# Altium schematic sheet Sheet1.SchDoc
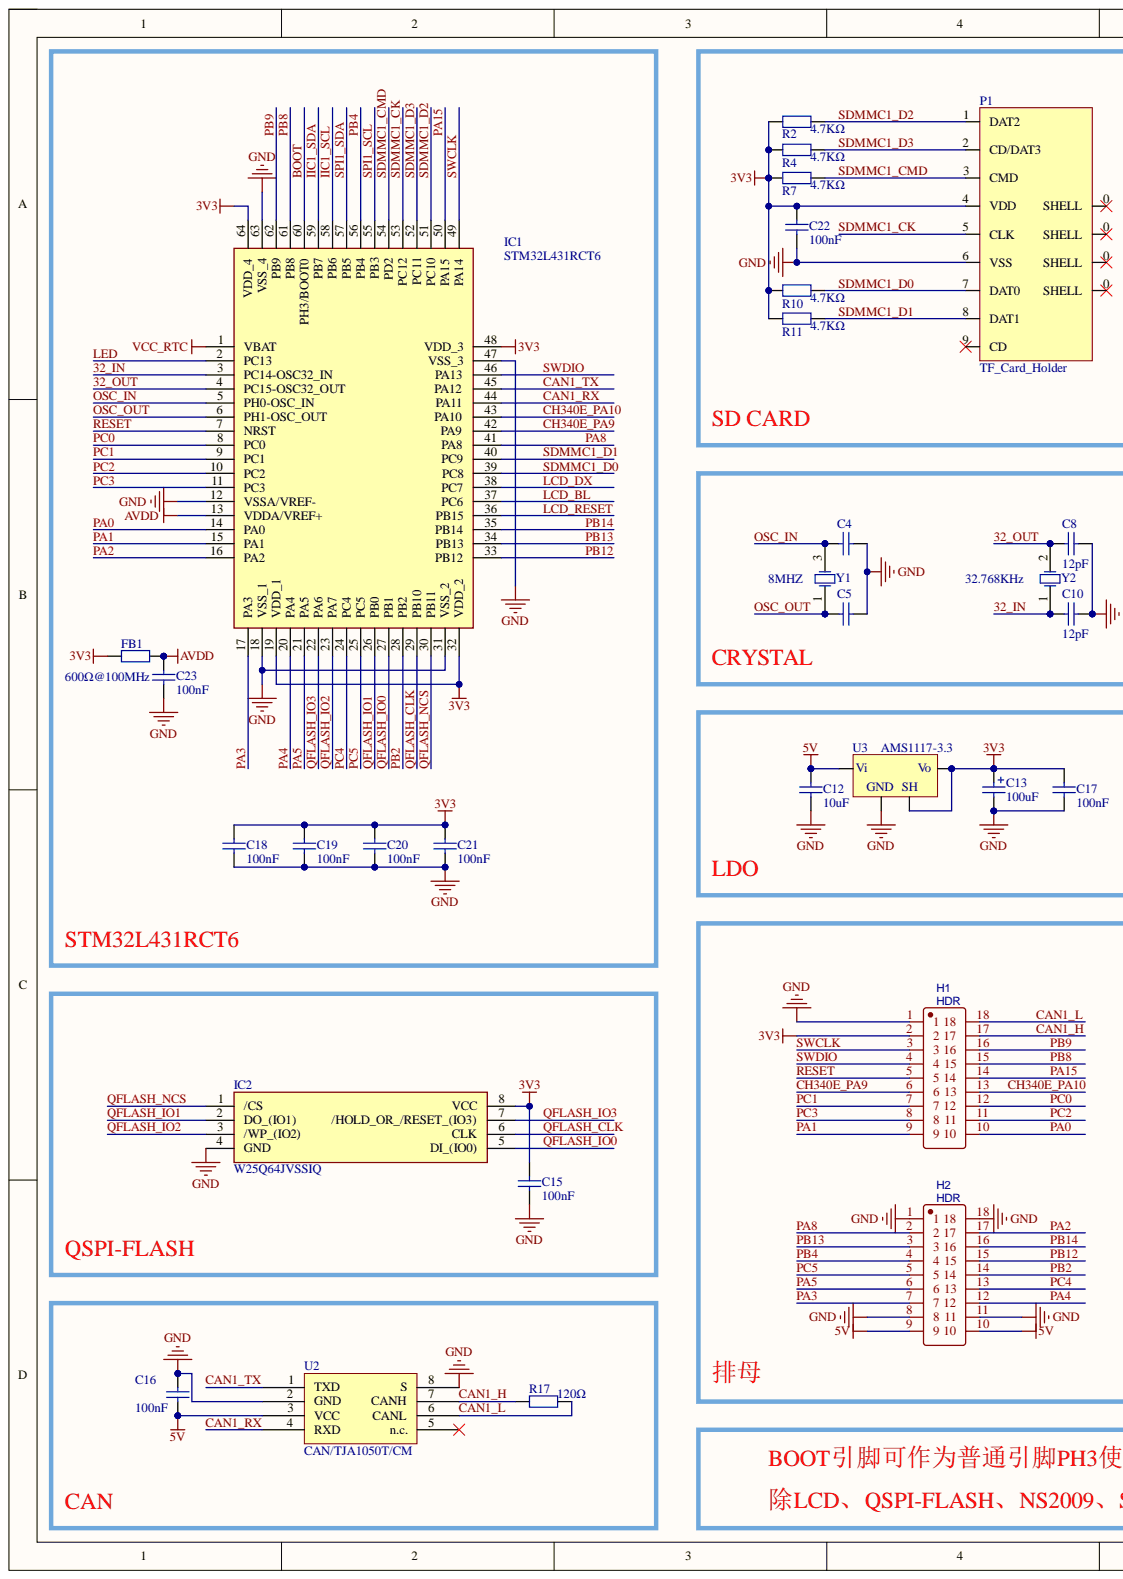
[diagram p0: Sheet1.SchDoc, left]
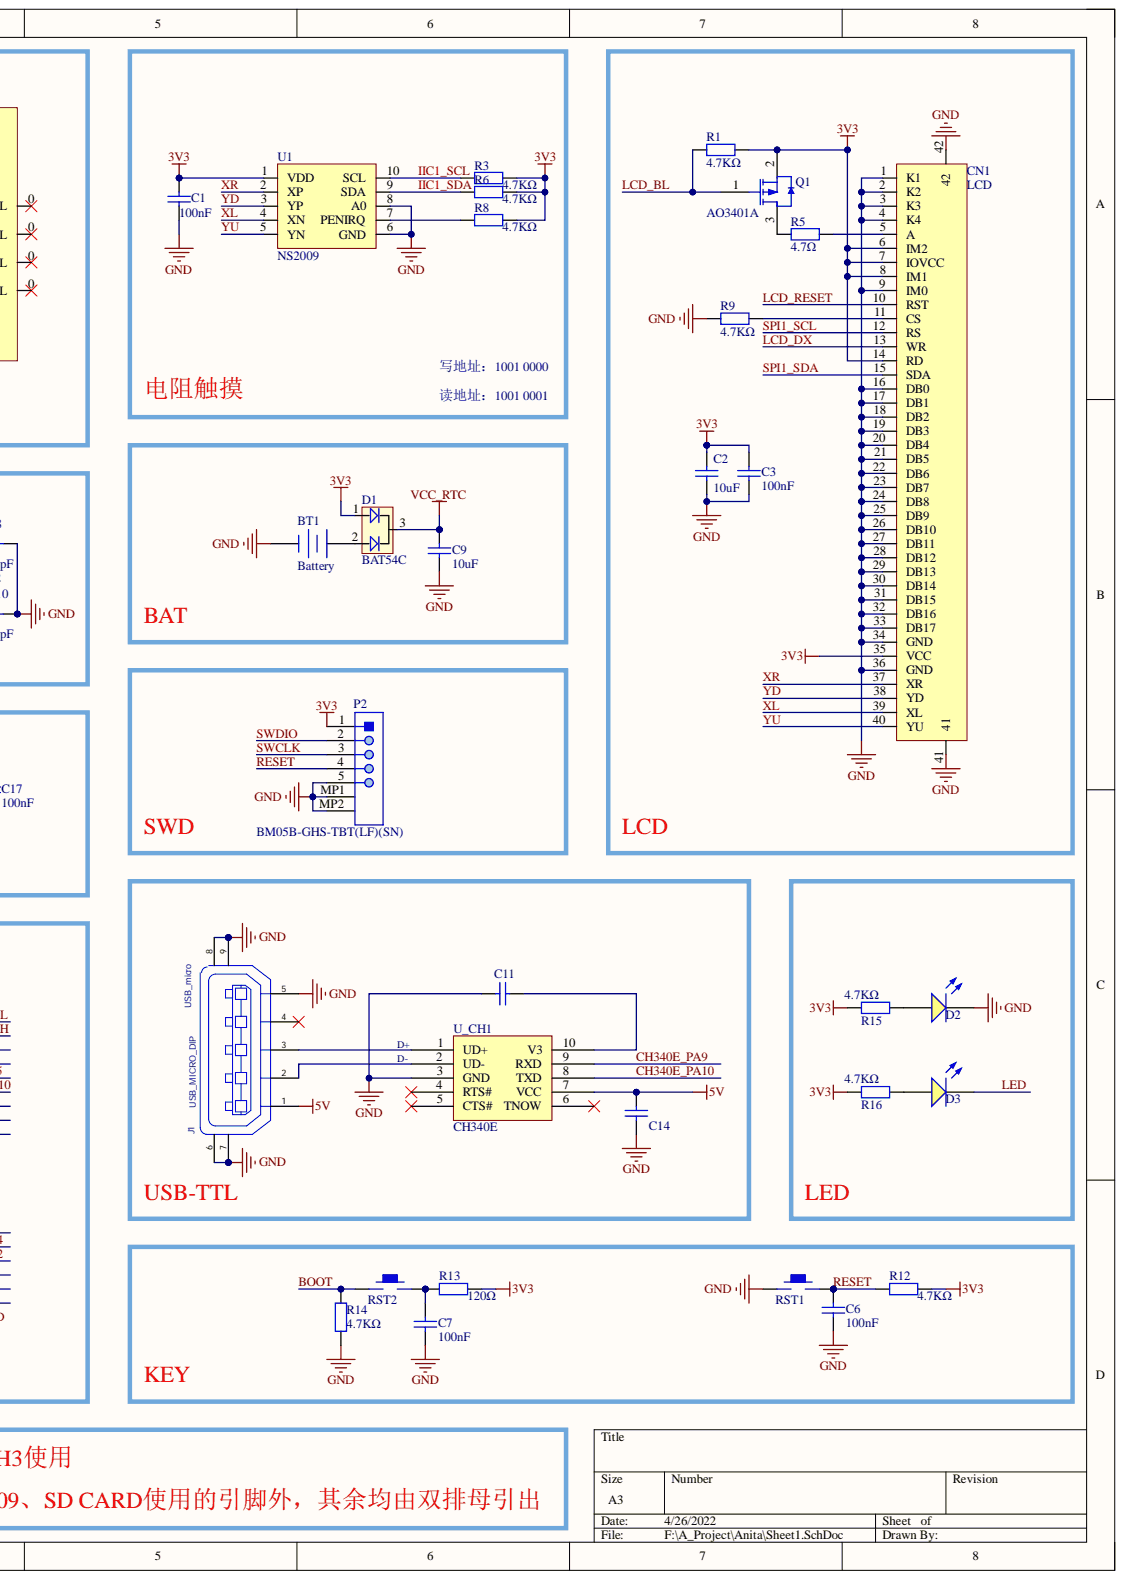
[diagram p1: Sheet1.SchDoc, right]

## Parts
BT1  Battery  [BATTERY]
C1  100nF  [0402_C]
C2  10uF  [0603_C]
C3  100nF  [0402_C]
C4  12pF  [0402_C]  (value not drawn)
C5  12pF  [0402_C]  (value not drawn)
C6  100nF  [0402_C]
C7  100nF  [0402_C]
C8  12pF  [0402_C]
C9  10uF  [0603_C]
C10  12pF  [0402_C]
C11  100nF (104) 10% 50V  [0402_C]  (value not drawn)
C12  10uF  [0603_C]
C13  100uF  [CASE-B_3528]
C14  100nF (104) 10% 50V  [0402_C]  (value not drawn)
C15  100nF  [0402_C]
C16  100nF  [0402_C]
C17  100nF  [0402_C]
C18  100nF  [0402_C]
C19  100nF  [0402_C]
C20  100nF  [0402_C]
C21  100nF  [0402_C]
C22  100nF  [0402_C]
C23  100nF  [0402_C]
CN1  LCD  [FPC-SMD_40P-P0.50_HDGC_0.5K-DX-40PWB]
D1  BAT54C  [SOT95P240X120-3N]
D2  黄灯 0603  [0603_LED_S1]  (value not drawn)
D3  黄灯 0603  [0603_LED_S1]  (value not drawn)
FB1  600Ω@100MHz  [0603_R]
H1  HDR  [HDR-SMD_18P-P2.54-V-M-R2-C9-S7.50]
H2  HDR  [HDR-SMD_18P-P2.54-V-M-R2-C9-S7.50]
IC1  STM32L431RCT6  [QFP50P1200X1200X160-64N]
IC2  W25Q64JVSSIQ  [SOIC127P790X216-8N]
J1  USB_MICRO_DIP  value USB_micro  [MICRO_USB_5S]
P1  TF_Card_Holder  [SD-MICRO-TF]
P2  BM05B-GHS-TBT(LF)(SN)  [CONN-SMD_5P-P1.25_HCTL_HC-1.25-5PWT]
Q1  AO3401A  [SOT-23-3L]
R1  4.7KΩ  [0402_R]
R2  4.7KΩ  [0402_R]
R3  4.7KΩ  [0402_R]
R4  4.7KΩ  [0402_R]
R5  4.7Ω  [0402_R]
R6  4.7KΩ  [0402_R]
R7  4.7KΩ  [0402_R]
R8  4.7KΩ  [0402_R]
R9  4.7KΩ  [0402_R]
R10  4.7KΩ  [0402_R]
R11  4.7KΩ  [0402_R]
R12  4.7KΩ  [0402_R]
R13  120Ω  [0402_R]
R14  4.7KΩ  [0402_R]
R15  4.7KΩ  [0402_R]
R16  4.7KΩ  [0402_R]
R17  120Ω  [0402_R]
RST1  S-KEY-SMD-324225  [KEY-SOP324225]  (value not drawn)
RST2  S-KEY-SMD-324225  [KEY-SOP324225]  (value not drawn)
U1  NS2009  [MSOP-10_L3.0-W3.0-P0.50-LS5.0-BL]
U2  CAN/TJA1050T/CM  [SOIC-8_150mil]
U3  AMS1117-3.3  [SOT-223]
U_CH1  CH340E  [MSOP-10_L3.0-W3.0-P0.50-LS5.0-BL]
Y1  8MHZ  [SMD-3225_4P]
Y2  32.768KHz  [SMD-3215_2P FC - 135]

## Nets
3V3: C1.1 C2.2 C3.2 C13.1 C15.1 C17.1 C18.1 C19.1 C20.1 C21.1 C22.1 CN1.6(IM2) CN1.7(IOVCC) CN1.8(IM1) CN1.14(RD) CN1.35(VCC) D1.1 FB1.1 H1.2 IC1.19(VDD_1) IC1.32(VDD_2) IC1.48(VDD_3) IC1.64(VDD_4) IC2.8(VCC) P1.4(VDD) P2.1 Q1.2 R1.2 R2.1 R3.2 R4.1 R6.2 R7.1 R8.2 R10.2 R11.2 R12.1 R13.2 R15.2 R16.2 U1.1(VDD) U3.2(Vo) U3.4(SH)
5V: C12.2 C14.2 C16.2 H2.9 H2.10 J1.1 U2.3(VCC) U3.3(Vi) U_CH1.7(VCC)
AVDD: C23.2 FB1.2 IC1.13(VDDA/VREF+)
GND: BT1.2 C1.2 C2.1 C3.1 C4.2 C5.2 C6.2 C7.2 C8.1 C9.1 C10.1 C11.1 C12.1 C13.2 C14.1 C15.2 C16.1 C17.2 C18.2 C19.2 C20.2 C21.2 C22.2 C23.1 CN1.1(K1) CN1.2(K2) CN1.3(K3) CN1.4(K4) CN1.9(IM0) CN1.16(DB0) CN1.17(DB1) CN1.18(DB2) CN1.19(DB3) CN1.20(DB4) CN1.21(DB5) CN1.22(DB6) CN1.23(DB7) CN1.24(DB8) CN1.25(DB9) CN1.26(DB10) CN1.27(DB11) CN1.28(DB12) CN1.29(DB13) CN1.30(DB14) CN1.31(DB15) CN1.32(DB16) CN1.33(DB17) CN1.34(GND) CN1.36(GND) CN1.41 CN1.42 D2.K H1.1 H2.1 H2.8 H2.11 H2.18 IC1.12(VSSA/VREF-) IC1.18(VSS_1) IC1.31(VSS_2) IC1.47(VSS_3) IC1.63(VSS_4) IC2.4(GND) J1.5 J1.6 J1.7 J1.8 J1.9 P1.6(VSS) P2.5 P2.MP1 P2.MP2 R9.2 R14.2 RST1.2 U1.6(GND) U1.8(A0) U2.2(GND) U2.8(S) U3.1 U_CH1.3(GND)
VCC_RTC: C9.2 D1.3 IC1.1(VBAT)
32_IN: C10.2 IC1.3(PC14-OSC32_IN) Y2.1
32_OUT: C8.2 IC1.4(PC15-OSC32_OUT) Y2.2
BOOT: IC1.60(PH3/BOOT0) R14.1 RST2.2
CAN1_H: H1.17 R17.1 U2.7(CANH)
CAN1_L: H1.18 R17.2 U2.6(CANL)
CAN1_RX: IC1.44(PA11) U2.4(RXD)
CAN1_TX: IC1.45(PA12) U2.1(TXD)
CH340E_PA9: H1.6 IC1.42(PA9) U_CH1.9(RXD)
CH340E_PA10: H1.13 IC1.43(PA10) U_CH1.8(TXD)
IIC1_SCL: IC1.58(PB6) R3.1 U1.10(SCL)
IIC1_SDA: IC1.59(PB7) R6.1 U1.9(SDA)
LCD_BL: IC1.37(PC6) Q1.1 R1.1
LCD_DX: CN1.13(WR) IC1.38(PC7)
LCD_RESET: CN1.10(RST) IC1.36(PB15)
LED: D3.K IC1.2(PC13)
OSC_IN: C4.1 IC1.5(PH0-OSC_IN) Y1.3
OSC_OUT: C5.1 IC1.6(PH1-OSC_OUT) Y1.1
PA0: H1.10 IC1.14(PA0)
PA1: H1.9 IC1.15(PA1)
PA2: H2.17 IC1.16(PA2)
PA3: H2.7 IC1.17(PA3)
PA4: H2.12 IC1.20(PA4)
PA5: H2.6 IC1.21(PA5)
PA8: H2.2 IC1.41(PA8)
PA15: H1.14 IC1.50(PA15)
PB2: H2.14 IC1.28(PB2)
PB4: H2.4 IC1.56(PB4)
PB8: H1.15 IC1.61(PB8)
PB9: H1.16 IC1.62(PB9)
PB12: H2.15 IC1.33(PB12)
PB13: H2.3 IC1.34(PB13)
PB14: H2.16 IC1.35(PB14)
PC0: H1.12 IC1.8(PC0)
PC1: H1.7 IC1.9(PC1)
PC2: H1.11 IC1.10(PC2)
PC3: H1.8 IC1.11(PC3)
PC4: H2.13 IC1.24(PC4)
PC5: H2.5 IC1.25(PC5)
QFLASH_CLK: IC1.29(PB10) IC2.6(CLK)
QFLASH_IO0: IC1.27(PB1) IC2.5(DI_(IO0))
QFLASH_IO1: IC1.26(PB0) IC2.2(DO_(IO1))
QFLASH_IO2: IC1.23(PA7) IC2.3(/WP_(IO2))
QFLASH_IO3: IC1.22(PA6) IC2.7(/HOLD_OR_/RESET_(IO3))
QFLASH_NCS: IC1.30(PB11) IC2.1(/CS)
RESET: C6.1 H1.5 IC1.7(NRST) P2.4 R12.2 RST1.1
SDMMC1_CK: IC1.53(PC12) P1.5(CLK)
SDMMC1_CMD: IC1.54(PD2) P1.3(CMD) R7.2
SDMMC1_D0: IC1.39(PC8) P1.7(DAT0) R10.1
SDMMC1_D1: IC1.40(PC9) P1.8(DAT1) R11.1
SDMMC1_D2: IC1.51(PC10) P1.1(DAT2) R2.2
SDMMC1_D3: IC1.52(PC11) P1.2(CD/DAT3) R4.2
SPI1_SCL: CN1.12(RS) IC1.55(PB3)
SPI1_SDA: CN1.15(SDA) IC1.57(PB5)
SWCLK: H1.3 IC1.49(PA14) P2.3
SWDIO: H1.4 IC1.46(PA13) P2.2
XL: CN1.39(XL) U1.4(XN)
XR: CN1.37(XR) U1.2(XP)
YD: CN1.38(YD) U1.3(YP)
YU: CN1.40(YU) U1.5(YN)
NetBT1_1: BT1.1 D1.2
NetC7_1: C7.1 R13.1 RST2.1
NetC11_2: C11.2 U_CH1.10(V3)
NetCN1_5: CN1.5(A) R5.2
NetCN1_11: CN1.11(CS) R9.1
NetD2_A: D2.A R15.1
NetD3_A: D3.A R16.1
NetJ1_2: J1.2 U_CH1.2(UD-)
NetJ1_3: J1.3 U_CH1.1(UD+)
NetQ1_3: Q1.3 R5.1
NetR8_1: R8.1 U1.7(PENIRQ)

Not connected: J1 4; P1 0(SHELL) 9(CD); U2 5(n.c.); U_CH1 4(RTS#) 5(CTS#) 6(TNOW)

## Library data of the parts
BT1 = Battery: Multicell Battery; Component Kind: Standard; LatestRevisionDate: 17-Jul-2002; LatestRevisionNote: Re-released for DXP Platform.; Library Name: Schlib1.SchLib; PackageDescription: Battery; 2 Leads; PackageReference: BAT-2; Published: 24-Mar-1999; Publisher: Altium Limited
C1 C3 C6 C7 C15-C23 = 0402 100nF (104) 10% 16V: 贴片电容; Component Kind: Standard; ComponentLink1Description: 供应商链接; ComponentLink1URL: http://www.szlcsc.com/product/details_1877.html; Library Name: Miscellaneous Devices LC.IntLib; Package: 0402; Supplier: LC; SuppliersPartNumber: C1525
C2 = 0603 10uF (106) 10% 10V: 贴片电容; Component Kind: Standard; ComponentLink1Description: 供应商链接; ComponentLink1URL: http://www.szlcsc.com/product/details_20411.html; Library Name: Miscellaneous Devices LC.IntLib; Package: 0603; Supplier: LC; SuppliersPartNumber: C19702
C4 C5 = 0402 20pF (200) 5% 50V: 贴片电容; Component Kind: Standard; ComponentLink1Description: 供应商链接; ComponentLink1URL: http://www.szlcsc.com/product/details_1906.html; Library Name: Miscellaneous Devices LC.IntLib; Package: 0402; Supplier: LC; SuppliersPartNumber: C1554
C8 C10 = 0402 10pF (100) 5% 50V: 贴片电容; Component Kind: Standard; ComponentLink1Description: 供应商链接; ComponentLink1URL: http://www.szlcsc.com/product/details_33914.html; Library Name: Miscellaneous Devices LC.IntLib; Package: 0402; Supplier: LC; SuppliersPartNumber: C32949
C9 = 0603 4.7uF (475) 10% 16V: 贴片电容; Component Kind: Standard; ComponentLink1Description: 供应商链接; ComponentLink1URL: http://www.szlcsc.com/product/details_20375.html; Library Name: Miscellaneous Devices LC.IntLib; Package: 0603; Supplier: LC; SuppliersPartNumber: C19666
C11 C14 = 0603 100nF (104) 10% 50V: 贴片电容; Component Kind: Standard; ComponentLink1Description: 供应商链接; ComponentLink1URL: http://www.szlcsc.com/product/details_15331.html; Library Name: Miscellaneous Devices LC.IntLib; Package: 0603; Supplier: LC; SuppliersPartNumber: C14663
C12 = 0805 10uF (106) 10% 25V: 贴片电容; Component Kind: Standard; ComponentLink1Description: 供应商链接; ComponentLink1URL: http://www.szlcsc.com/product/details_16532.html; Library Name: Miscellaneous Devices LC.IntLib; Package: 0805; Supplier: LC; SuppliersPartNumber: C15850
C13 = 3528 100uF (107) 10% 6.3V: 钽电容; Component Kind: Standard; ComponentLink1Description: 供应商链接; ComponentLink1URL: http://www.szlcsc.com/product/details_16815.html; Library Name: Miscellaneous Devices LC.IntLib; Package: 3528; Supplier: LC; SuppliersPartNumber: C16133
CN1 = FPC_40P_LCD: Component Kind: Standard; Library Name: K210_Core.SCHLIB
D1 = BAT54C: 双肖特基二极管; Component Kind: Standard; Library Name: SamacSys.SchLib
D2 D3 = 0603 黄灯: 发光二极管; Component Kind: Standard; ComponentLink1Description: 供应商链接; ComponentLink1URL: http://www.szlcsc.com/product/details_73147.html; Library Name: Miscellaneous Devices LC.IntLib; Package: 0603; Supplier: LC; SuppliersPartNumber: C72038
FB1 = 0603 100Ω (1000) 1%: 贴片电阻; Component Kind: Standard; ComponentLink1Description: 供应商链接; ComponentLink1URL: http://www.szlcsc.com/product/details_23502.html; Library Name: Miscellaneous Devices LC.IntLib; Package: 0603; Supplier: LC; SuppliersPartNumber: C22775
H1 H2 = HDR: BOM_Manufacturer: BOOMELE(博穆精密); BOM_Manufacturer Part: 2.54-2*9P立贴针带柱; Contributor: LCSC; BOM_JLCPCB Part Class: Extended Part; BOM_Supplier: LCSC; BOM_Supplier Part: C88175; Component Kind: Standard; spicePre: H; spiceSymbolName: HDR
IC1 = STM32L431RCT6: Integrated Circuit; Manufacturer_Name: STMicroelectronics; Arrow Part Number: STM32L431RCT6; Arrow Price/Stock: https://www.arrow.com/en/products/stm32l431rct6/stmicroelectronics?region=nac; Component Kind: Standard; Datasheet Link: https://www.st.com/resource/en/datasheet/stm32l431rc.pdf; Height: 1.6mm; Manufacturer_Part_Number: STM32L431RCT6; Mouser Part Number: 511-STM32L431RCT6; Mouser Price/Stock: https://www.mouser.co.uk/ProductDetail/STMicroelectronics/STM32L431RCT6?qs=U58vgkJzipQt4Fiogdks1w%3D%3D
IC2 = W25Q128FVSIG: Integrated Circuit; Manufacturer_Name: Winbond; Arrow Part Number: W25Q128FVSIG; Arrow Price/Stock: https://www.arrow.com/en/products/w25q128fvsig/winbond-electronics; Component Kind: Standard; Datasheet Link: https://www.winbond.com/resource-files/w25q128fv rev.m 05132016 kms.pdf; Height: 2.16mm; Manufacturer_Part_Number: W25Q128FVSIG; Mouser Part Number: N/A; Mouser Price/Stock: https://www.mouser.co.uk/ProductDetail/Winbond/W25Q128FVSIG?qs=rkhjVJ6%2F3EIwu4pV702YLQ%3D%3D
P2 = BM05B-GHS-TBT(LF)(SN): C2845382; Manufacturer: JST; No of Positions: 5; Pitch: 1.25mm; Style/Orientation: StraightHeader/Plug; Component Kind: Standard; Datasheet URL: http://www.jst-mfg.com/product/pdf/eng/eGH.pdf; Datasheet Version: 05/2016; Library Name: JST GH connector.IntLib; Manufacturer URL: http://www.jst.com/home2.html; Mounting Technology: SurfaceMount; Package Description: GH Disconnectable Crimp Style Connector, Pitch 1.25 mm, 1 x 5 Position, Height 4.05 mm; Package Reference: BM05B-GHS-TBT; Termination: Crimp; Type: WiretoBoard
Q1 = AO3401A P道沟 X13A15 SOT-23: MOS(场效应管); Component Kind: Standard; ComponentLink1Description: 供应商链接; ComponentLink1URL: http://www.szlcsc.com/product/details_15801.html; Library Name: Miscellaneous Devices LC.IntLib; Package: SOT-23; Supplier: LC; SuppliersPartNumber: C15127
R1 R3 R5 R6 R8 R9 R12 R14 = 0402 10KΩ (1002) 1%: 贴片电阻; Component Kind: Standard; ComponentLink1Description: 供应商链接; ComponentLink1URL: http://www.szlcsc.com/product/details_26487.html; Library Name: Miscellaneous Devices LC.IntLib; Package: 0402; Supplier: LC; SuppliersPartNumber: C25744
R2 R4 R7 R10 R11 R15 R16 = 0402 4.7KΩ (4701) 1%: 贴片电阻; Component Kind: Standard; ComponentLink1Description: 供应商链接; ComponentLink1URL: http://www.szlcsc.com/product/details_26643.html; Library Name: Miscellaneous Devices LC.IntLib; Package: 0402; Supplier: LC; SuppliersPartNumber: C25900
R13 R17 = 0402 120Ω (1200) 1%: 贴片电阻; Component Kind: Standard; ComponentLink1Description: 供应商链接; ComponentLink1URL: http://www.szlcsc.com/product/details_25822.html; Library Name: Miscellaneous Devices LC.IntLib; Package: 0402; Supplier: LC; SuppliersPartNumber: C25079
RST1 RST2 = S-KEY-SMD-324225: KEY,SMD,324225; Component Kind: Standard; Library Name: ALIENTEK_W601_Board_V1.51.IntLib
U2 = CAN/TJA1050T/CM_[C6952]: CAN; Component Kind: Standard; ComponentLink1Description: 供应商链接; ComponentLink1URL: http://www.szlcsc.com/product/details_7424.html; Library Name: Miscellaneous Devices LC.IntLib; Package: SOIC-8_150mil; Supplier: LC; SuppliersPartNumber: C6952
U3 = LDO-AMS1117-3.3: LDO,1A; Component Kind: Standard; Library Name: ALIENTEK_W601_Board_V1.51.IntLib
Y1 = SMD-3225_4P  16MHZ 9PF 10PPM: 贴片晶振; Component Kind: Standard; ComponentLink1Description: 供应商链接; ComponentLink1URL: http://www.szlcsc.com/product/details_14393.html; Library Name: Miscellaneous Devices LC.IntLib; Package: SMD-3225_4P; Supplier: LC; SuppliersPartNumber: C13738
Y2 = SMD-3215_2P 32.768KHz 20ppm 12.5pF: 贴片晶振; Component Kind: Standard; ComponentLink1Description: 供应商链接; ComponentLink1URL: http://www.szlcsc.com/product/details_33310.html; Library Name: Miscellaneous Devices LC.IntLib; Package: SMD-3215_2P; Supplier: LC; SuppliersPartNumber: C32346
J1 = USB_MICRO_DIP: Component Kind: Standard; PCB DECAL: MICRO_USB_5S; Library Name: 野火F429_F746_F767核心板.IntLib
P1 = TF_Card_Holder: Component Kind: Standard; Library Name: ALIENTEK_W601_Board_V1.51.IntLib
U1 = NS2009: Component Kind: Standard; Library Name: K210_Core.SCHLIB
U_CH1 = CH340E: Component Kind: Standard; Library Name: PCB_Project.IntLib
